# Altium schematic sheet ROBOTAIX_2015.SchDoc
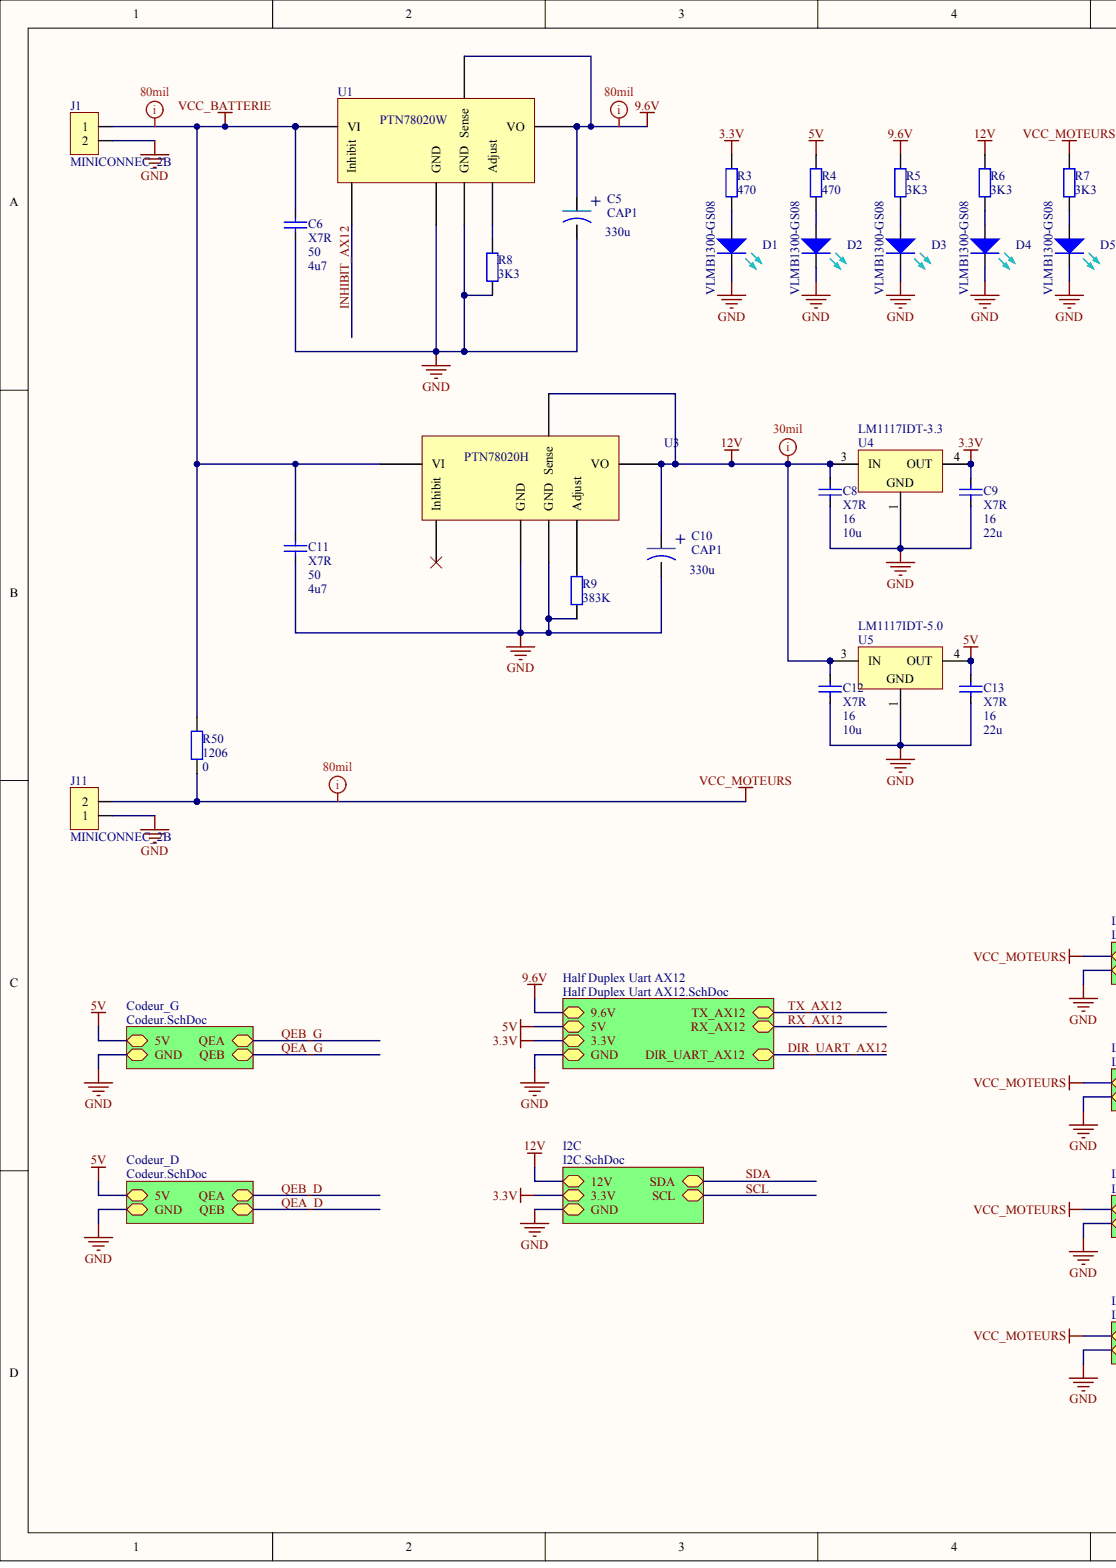
[diagram p0: ROBOTAIX_2015.SchDoc, left]
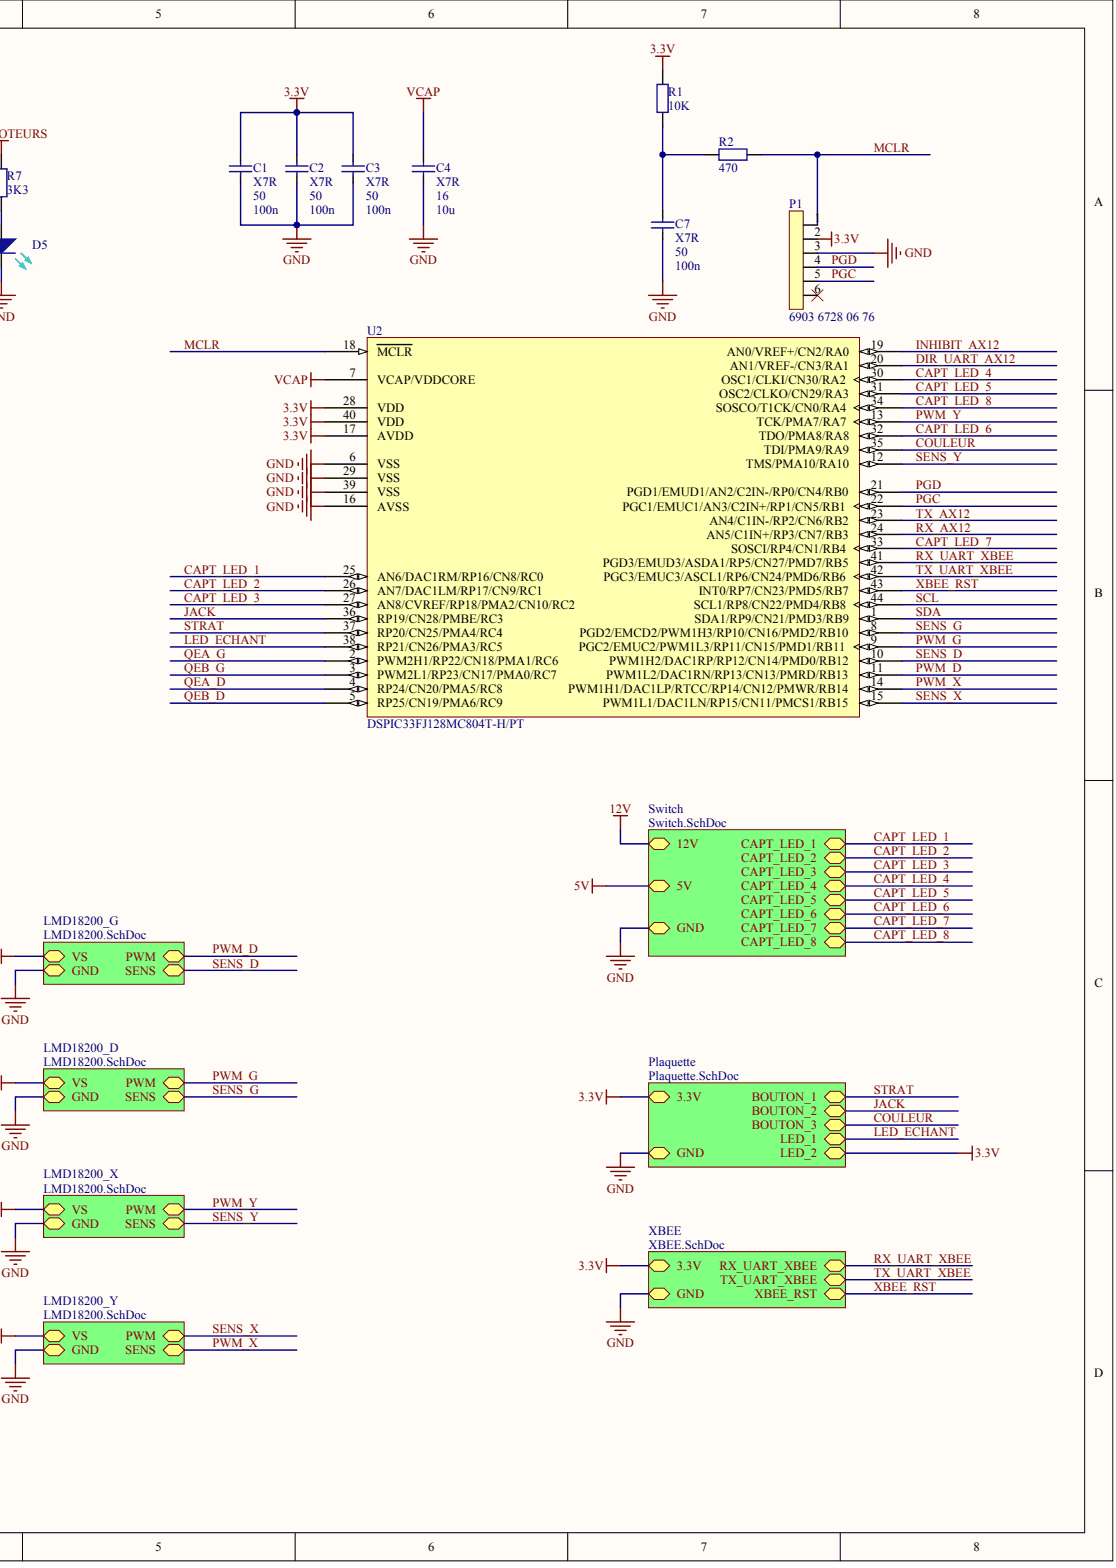
[diagram p1: ROBOTAIX_2015.SchDoc, right]

## Parts
C1  Type: X7R  (hidden comment: 100n)
C2  Type: X7R  (hidden comment: 100n)
C3  Type: X7R  (hidden comment: 100n)
C4  Type: X7R  (hidden comment: 10u)
C5  CAP1
C6  Type: X7R  (hidden comment: 4u7)
C7  Type: X7R  (hidden comment: 100n)
C8  Type: X7R  (hidden comment: 10u)
C9  Type: X7R  (hidden comment: 22u)
C10  CAP1
C11  Type: X7R  (hidden comment: 4u7)
C12  Type: X7R  (hidden comment: 10u)
C13  Type: X7R  (hidden comment: 22u)
D1  VLMB1300-GS08
D2  VLMB1300-GS08
D3  VLMB1300-GS08
D4  VLMB1300-GS08
D5  VLMB1300-GS08
J1  MINICONNEC_2B
J11  MINICONNEC_2B
P1  6903 6728 06 76
R1  10K
R2  470
R3  470
R4  470
R5  3K3
R6  3K3
R7  3K3
R8  3K3
R9  383K
R50  Boîtier: 1206  (hidden comment: 0)
U1  PTN78020W
U2  DSPIC33FJ128MC804T-H/PT
U3  PTN78020H
U4  LM1117IDT-3.3
U5  LM1117IDT-5.0

## Nets
3.3V: C1.2 C2.2 C3.2 C9.2 P1.2 R1.2 R3.2 U2.17(AVDD) U2.28(VDD) U2.40(VDD) U4.4
5V: C13.2 R4.2 U5.4
9.6V: C5.1 R5.2 U1.5(Sense) U1.6(VO)
12V: C8.2 C10.1 C12.2 R6.2 U3.5(Sense) U3.6(VO) U4.3 U5.3
GND: C1.1 C2.1 C3.1 C4.1 C5.2 C6.1 C7.1 C8.1 C9.1 C10.2 C11.1 C12.1 C13.1 D1.K D2.K D3.K D4.K D5.K J1.2 J11.1 P1.3 R8.1 R9.1 U1.1(GND) U1.7(GND) U2.6(VSS) U2.16(AVSS) U2.29(VSS) U2.39(VSS) U3.1(GND) U3.7(GND) U4.1 U5.1
VCAP: C4.2 U2.7(VCAP/VDDCORE)
VCC_BATTERIE: C6.2 C11.2 J1.1 R50.2 U1.2(VI) U3.2(VI)
VCC_MOTEURS: J11.2 R7.2 R50.1
CAPT_LED_1: U2.25(AN6/DAC1RM/RP16/CN8/RC0)
CAPT_LED_2: U2.26(AN7/DAC1LM/RP17/CN9/RC1)
CAPT_LED_3: U2.27(AN8/CVREF/RP18/PMA2/CN10/RC2)
CAPT_LED_4: U2.30(OSC1/CLKI/CN30/RA2)
CAPT_LED_5: U2.31(OSC2/CLKO/CN29/RA3)
CAPT_LED_6: U2.32(TDO/PMA8/RA8)
CAPT_LED_7: U2.33(SOSCI/RP4/CN1/RB4)
CAPT_LED_8: U2.34(SOSCO/T1CK/CN0/RA4)
COULEUR: U2.35(TDI/PMA9/RA9)
DIR_UART_AX12: U2.20(AN1/VREF-/CN3/RA1)
INHIBIT_AX12: U1.3(Inhibit) U2.19(AN0/VREF+/CN2/RA0)
JACK: U2.36(RP19/CN28/PMBE/RC3)
LED_ECHANT: U2.38(RP21/CN26/PMA3/RC5)
MCLR: P1.1 R2.2 U2.18(MCLR)
PGC: P1.5 U2.22(PGC1/EMUC1/AN3/C2IN+/RP1/CN5/RB1)
PGD: P1.4 U2.21(PGD1/EMUD1/AN2/C2IN-/RP0/CN4/RB0)
PWM_D: U2.11(PWM1L2/DAC1RN/RP13/CN13/PMRD/RB13)
PWM_G: U2.9(PGC2/EMUC2/PWM1L3/RP11/CN15/PMD1/RB11)
PWM_X: U2.14(PWM1H1/DAC1LP/RTCC/RP14/CN12/PMWR/RB14)
PWM_Y: U2.13(TCK/PMA7/RA7)
QEA_D: U2.4(RP24/CN20/PMA5/RC8)
QEA_G: U2.2(PWM2H1/RP22/CN18/PMA1/RC6)
QEB_D: U2.5(RP25/CN19/PMA6/RC9)
QEB_G: U2.3(PWM2L1/RP23/CN17/PMA0/RC7)
RX_AX12: U2.24(AN5/C1IN+/RP3/CN7/RB3)
RX_UART_XBEE: U2.41(PGD3/EMUD3/ASDA1/RP5/CN27/PMD7/RB5)
SCL: U2.44(SCL1/RP8/CN22/PMD4/RB8)
SDA: U2.1(SDA1/RP9/CN21/PMD3/RB9)
SENS_D: U2.10(PWM1H2/DAC1RP/RP12/CN14/PMD0/RB12)
SENS_G: U2.8(PGD2/EMCD2/PWM1H3/RP10/CN16/PMD2/RB10)
SENS_X: U2.15(PWM1L1/DAC1LN/RP15/CN11/PMCS1/RB15)
SENS_Y: U2.12(TMS/PMA10/RA10)
STRAT: U2.37(RP20/CN25/PMA4/RC4)
TX_AX12: U2.23(AN4/C1IN-/RP2/CN6/RB2)
TX_UART_XBEE: U2.42(PGC3/EMUC3/ASCL1/RP6/CN24/PMD6/RB6)
XBEE_RST: U2.43(INT0/RP7/CN23/PMD5/RB7)
NetC7_2: C7.2 R1.1 R2.1
NetD1_A: D1.A R3.1
NetD2_A: D2.A R4.1
NetD3_A: D3.A R5.1
NetD4_A: D4.A R6.1
NetD5_A: D5.A R7.1
NetP1_6: P1.6
NetR8_2: R8.2 U1.4(Adjust)
NetR9_2: R9.2 U3.4(Adjust)
NetU3_3: U3.3(Inhibit)

## Library data of the parts
C1-C3 C7 = (no symbol name): Edition: Watt Consulting; Fabriquant: AVX; Prix (€): 0,049; Référence fabriquant: 06035C104KAT2A; Boîtier: 0603; Tolérance (%): 10; Code Radiospares: 698-3260; Tension (V): 50; Date de création: 02-Mars-2011; Derniére révision: 02-Mars-2011; Code Farnell: 1301804; Code Mouser: 581-06035C104KAT2A; Code Digikey: 478-5052-6-ND; Lien GCC: http://www.avx.com/docs/Catalogs/cx7r.pdf; Stabilité (ppm/K): ?; Status: Full Production; Value: 100n
C4 C8 C12 = (no symbol name): Edition: Watt Consulting; Fabriquant: AVX; Prix (€): 0,53; Référence fabriquant: 1206YC106KAT2A; Boîtier: 1206; Tolérance (%): 10; Code Radiospares: 698-3724; Tension (V): 16; Date de création: 18-Juillet-2011; Derniére révision: 18-Juillet-2011; Code Farnell: 1657943; Code Mouser: 581-1206YC106KAT2A; Code Digikey: 478-5725-6-ND; Lien GCC: http://www.avx.com/docs/Catalogs/cx7r.pdf; Stabilité (ppm/K): ?; Status: Full Production; Value: 10u
C6 C11 = (no symbol name): Edition: Watt Consulting; Fabriquant: AVX; Prix (€): 2,69; Référence fabriquant: 18125C475KAZ2A; Boîtier: 1812; Tolérance (%): 10; Code Radiospares: 698-3881; Tension (V): 50; Date de création: 17-Mars-2011; Derniére révision: 17-Mars-2011; Code Farnell: 1301819; Code Mouser: 581-18125C475KAZ2A; Code Digikey: y	18125C475KAZ2A-ND; Lien GCC: http://www.avx.com/docs/Catalogs/cx7r.pdf; Stabilité (ppm/K): ?; Status: Full Production; Value: 4u7
C9 C13 = (no symbol name): Date de création: 07-Décembre-2011; Edition: Watt Consulting; Fabriquant: AVX; Prix (€): 0,94; Référence fabriquant: 1210YC226KAT2A; Boîtier: 1210; Tolérance (%): 10; Code Radiospares: 698-3816; Tension (V): 16; Derniére révision: 07-Décembre-2011; Code Farnell: 1657948; Code Mouser: 581-1210YC226KAT2A; Code Digikey: 478-5729-6-ND; Lien GCC: http://www.avx.com/docs/Catalogs/cx7r.pdf; Stabilité (ppm/K): ?; Status: Full Production; Value: 22u
D1-D5 = (no symbol name): Angle de diffusion (°): 65; Boîtier: 0603; Code Radiospares: X; Courant (A): 0,02; Edition: Watt Consulting; Fabriquant: VISHAY; Pic de longueur d'onde (nm): 470; Prix (€): 0,109; Puissance (W): 0,075; Semi-conducteur: InGaN; Tension (V): 5; Type: Blue; Vd (V): 3,3; Equivalent 1: HSMR-C190 (AVAGO); Série: VLMx1300; Code Digikey: VLMB1300-GS08DKR-ND; Code Farnell: 2251459; Code Mouser: 78-VLMB1300-GS08; Date de création: 09-Mars-2013; Derniére révision: 09-Mars-2013; Lien GCC: http://www.vishay.com/docs/82437/vlmo1300.pdf; Poids (g): ?; Puissance lumineuse (mW): ?; Référence fabriquant: VLMB1300-GS08; Tr (ns): ?; Value: VLMB1300-GS08
P1 = (no symbol name): Edition: ECE ZODIAC; Fabriquant: WÜRTH ELEKTRONIK; Lien GCC: X; Prix (€): 1,66; Equivalent 1: 7-188275-6 (TYCO ELECTRONICS); Code Radiospares: 771-8109; Série: WERI; Remarque: WERI 06; Date de création: 13-Novembre-2006; Derniére révision: 13-Novembre-2006; Poids (g): ?; Référence fabriquant: 6903 6728 06 76; Code Farnell: 1641849; Code Mouser: 710-690367280676; Code Digikey: 732-1965-6-ND; Tension (V): ?; Type: Connecteur CMS 6 broches; Value: 6903 6728 06 76
R1-R9 = (no symbol name): Edition: Watt Consulting; Fabriquant: KOA Speer; Référence fabriquant: RK73H1JTTDxxxxF; Série: TBD; Tolérance (%): 1; Puissance (W): 0,1; Poids (g): 0,019; Prix (€): 0,066; Boîtier: 0603; Tension (V): 50; Derniére révision: 12-Septembre-2011; Date de création: 12-Septembre-2011; Lien GCC: http://www.koaspeer.com/catimages/Products/RK73H/RK73H.pdf; Code Mouser: 660-RK73H1JTTDxxxxF; Stabilité (ppm/K): TBD; Code Digikey: TBD; Code Farnell: TBD; Code Radiospares: TBD; Status: Full Production; Type: Precision 1% Tolerance Thick Film Chip Resistor; Ratio tolérance (%): -
  R1: Value: 10K
  R2-R4: Value: 470
  R5-R8: Value: 3K3
  R9: Value: 383K
R50 = (no symbol name): Edition: Watt Consulting; Fabriquant: KOA Speer; Référence fabriquant: RK73Z2BT; Série: E12/E24/E48/E96; Puissance (W): 0,25; Prix (€): 0,083; Remarque: 2A Max; Derniére révision: 29-Aout-2011; Date de création: 29-Aout-2011; Tolérance (%): -; Lien GCC: http://www.koaspeer.com/catimages/Products/RK73Z/RK73Z.pdf; Poids (g): ?; Code Mouser: 660-RK73Z2BTTD; Stabilité (ppm/K): -; Status: Full Production; Tension (V): 0; Type: Zero Ohm Jumper Chip Resistor; Ratio tolérance (%): -; Value: 0
U4 = (no symbol name): Manufacturer: National Semiconductor; CompType: LDO IC; PackageTopMarking: NSUZXYTT*LM1117*IDT-3.3; PowerWise: N; Published: 4-Dec-2008; DatasheetVersion: Apr-2006; PackageVersion: revC, Apr-2003; PackageReference: TD03B; PackageDescription: TO252, Molded, Jedec, 6.8 x 6.10 x 2.30mm Body, 3 Lead, 2.28mm Pitch, Surface Mount; PartNumber: LM1117IDT-3.3; RoHS: O; PinCount: 3; DigiKeyPartNumber: LM1117IDT-3.3-ND; Code_JEDEC: TO-252-AA; ComponentLink1Description: LM1117 Datasheet; ComponentLink1URL: http://www.national.com/ds/LM/LM1117.pdf; ComponentLink2Description: LM1117 Web Page; ComponentLink2URL: http://www.national.com/pf/LM/LM1117.html; ComponentLink3Description: TD03B Package Page; ComponentLink3URL: http://www.national.com/packaging/folders/td03b.html; Publisher: Altium Limited
U5 = (no symbol name): Manufacturer: National Semiconductor; CompType: LDO IC; PackageTopMarking: NSUZXYTT*LM1117*IDT-5.0; PowerWise: N; Published: 4-Dec-2008; DatasheetVersion: Apr-2006; PackageVersion: revC, Apr-2003; PackageReference: TD03B; PackageDescription: TO252, Molded, Jedec, 6.8 x 6.10 x 2.30mm Body, 3 Lead, 2.28mm Pitch, Surface Mount; PartNumber: LM1117IDT-5.0; RoHS: O; PinCount: 3; DigiKeyPartNumber: LM1117IDT-5.0-ND; Code_JEDEC: TO-252-AA; ComponentLink1Description: LM1117 Datasheet; ComponentLink1URL: http://www.national.com/ds/LM/LM1117.pdf; ComponentLink2Description: LM1117 Web Page; ComponentLink2URL: http://www.national.com/pf/LM/LM1117.html; ComponentLink3Description: TD03B Package Page; ComponentLink3URL: http://www.national.com/packaging/folders/td03b.html; Publisher: Altium Limited
C5 C10 J1 J11 U1-U3 = (no symbol name)
  U2: FirstPublished: 2-Dec-2009; DatasheetVersion: revD, Nov-2009; PackageVersion: revBB, Aug-2009; PackageReference: TQFP-PT44; PackageDescription: 44-Lead Plastic Thin Quad Flatpack (PT) - 10x10x1mm Body, 2.00mm [TQFP]; Code_JEDEC: MS-026; ComponentLink1Description: Datasheet; ComponentLink1URL: http://ww1.microchip.com/downloads/en/DeviceDoc/70291D.pdf; ComponentLink2Description: Packaging Specification; ComponentLink2URL: http://www.microchip.com/stellent/groups/techpub_sg/documents/packagingspec/en012702.pdf; ComponentLink3Description: Microchip.com Home; ComponentLink3URL: http://www.microchip.com/; Publisher: Altium Limited
